# Altium schematic sheet Breakout.SchDoc
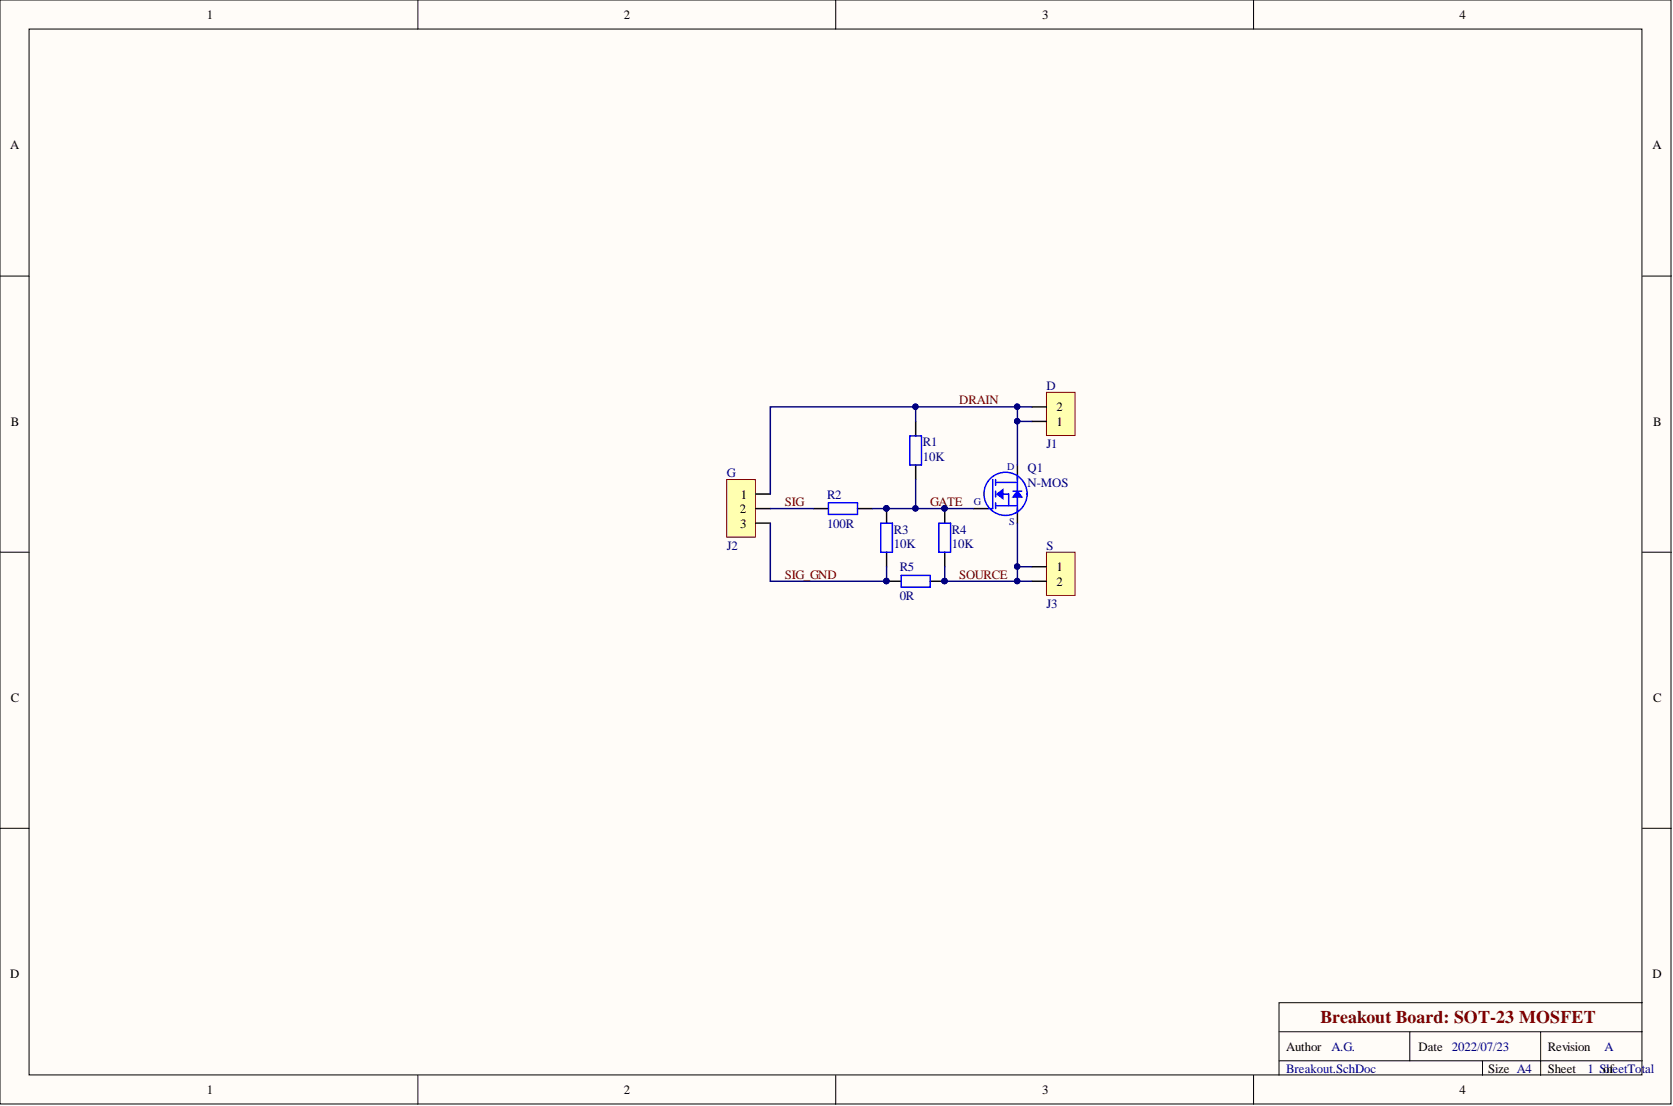
[diagram p0: Breakout.SchDoc]

## Parts
J1  D  [HEADER-2.54-2P1]
J2  G  [HEADER-2.54-3P1]
J3  S  [HEADER-2.54-2P1]
Q1  N-MOS  [IRLML_SOT-23]
R1  10K  [0603R_RF_M]
R2  100R  [0603R_RF_M]
R3  10K  [0603R_RF_M]
R4  10K  [0603R_RF_M]
R5  0R  [0603R_RF_M]

## Nets
DRAIN: J1.1 J1.2 J2.1 Q1.D R1.1
GATE: Q1.G R1.2 R2.2 R3.1 R4.1
SIG: J2.2 R2.1
SIG_GND: J2.3 R3.2 R5.2
SOURCE: J3.1 J3.2 Q1.S R4.2 R5.1

## Library data of the parts
J1 J3 = PINHDR-2P1: Pin Header Single Row 2 Positions; Component Kind: Standard; Mounting Technology: THT; Library Name: AG.Connectors.SchLib
J2 = PINHDR-3P1: Generic Pin Header 3x1; Component Kind: Standard; Library Name: AG.Connectors.SchLib
Q1 = N-MOS: MOSFET N-Channel; Manufacturer: Generic Brand; IGS: ? A; Power Rating: ? W; VDS: ? V; Category: Transistor; Component Kind: Standard; Mounting Technology: SMT; Supplier Link: http://; VGS: ? V; Library Name: AG.Generic.SchLib
R1-R5 = R: Resistor; Manufacturer: Generic Brand; Max Voltage: ? V; Power Rating: ? W; Category: Resistor; Component Kind: Standard; Library Name: AG.Generic.SchLib; Mounting Technology: SMT; Supplier Link: http://; Tolerance: ?
  R1 R3 R4: Value: 10K
  R2: Value: 100R
  R5: Value: 0R
